# Altium schematic sheet HDMI.SchDoc
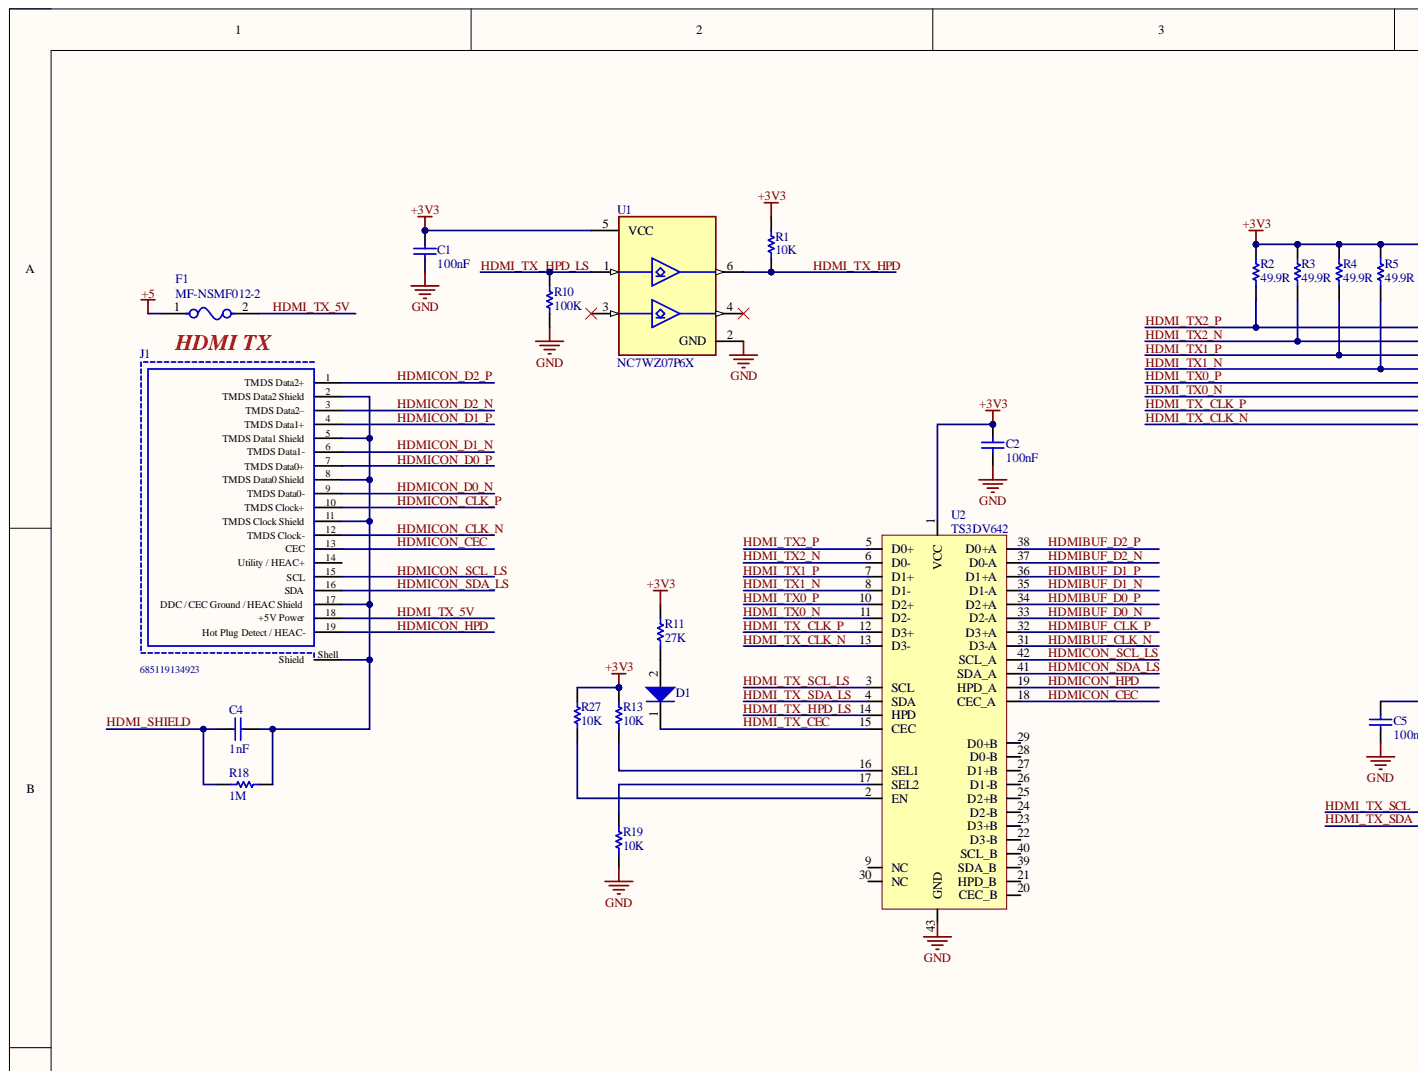
[diagram p0: HDMI.SchDoc, top left]
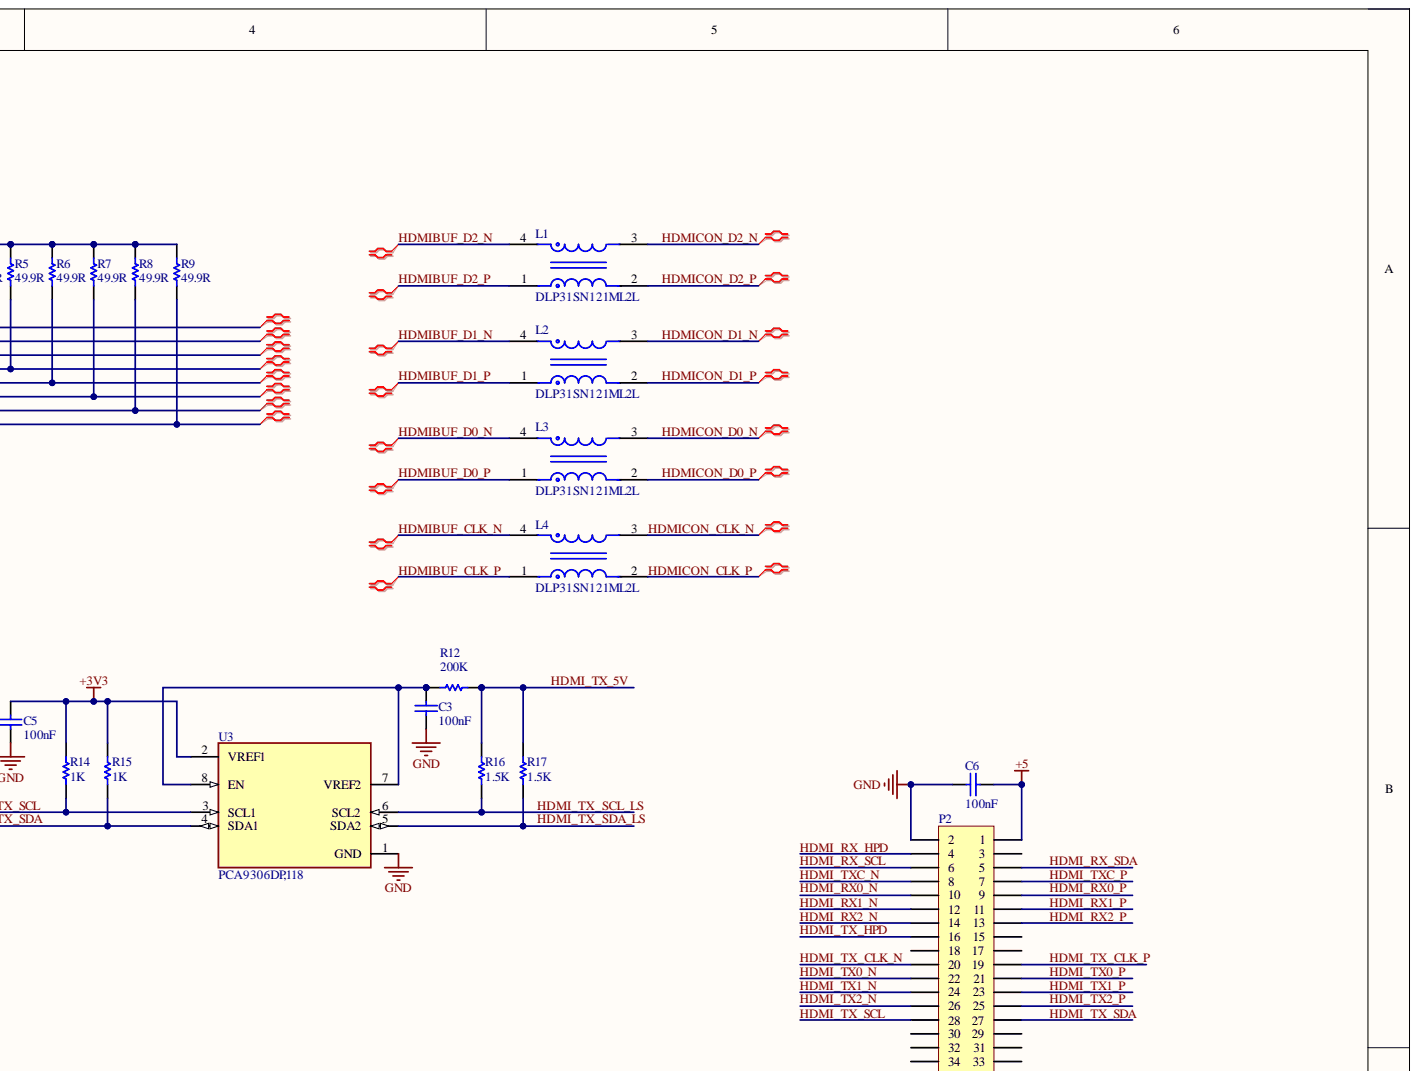
[diagram p1: HDMI.SchDoc, top right]
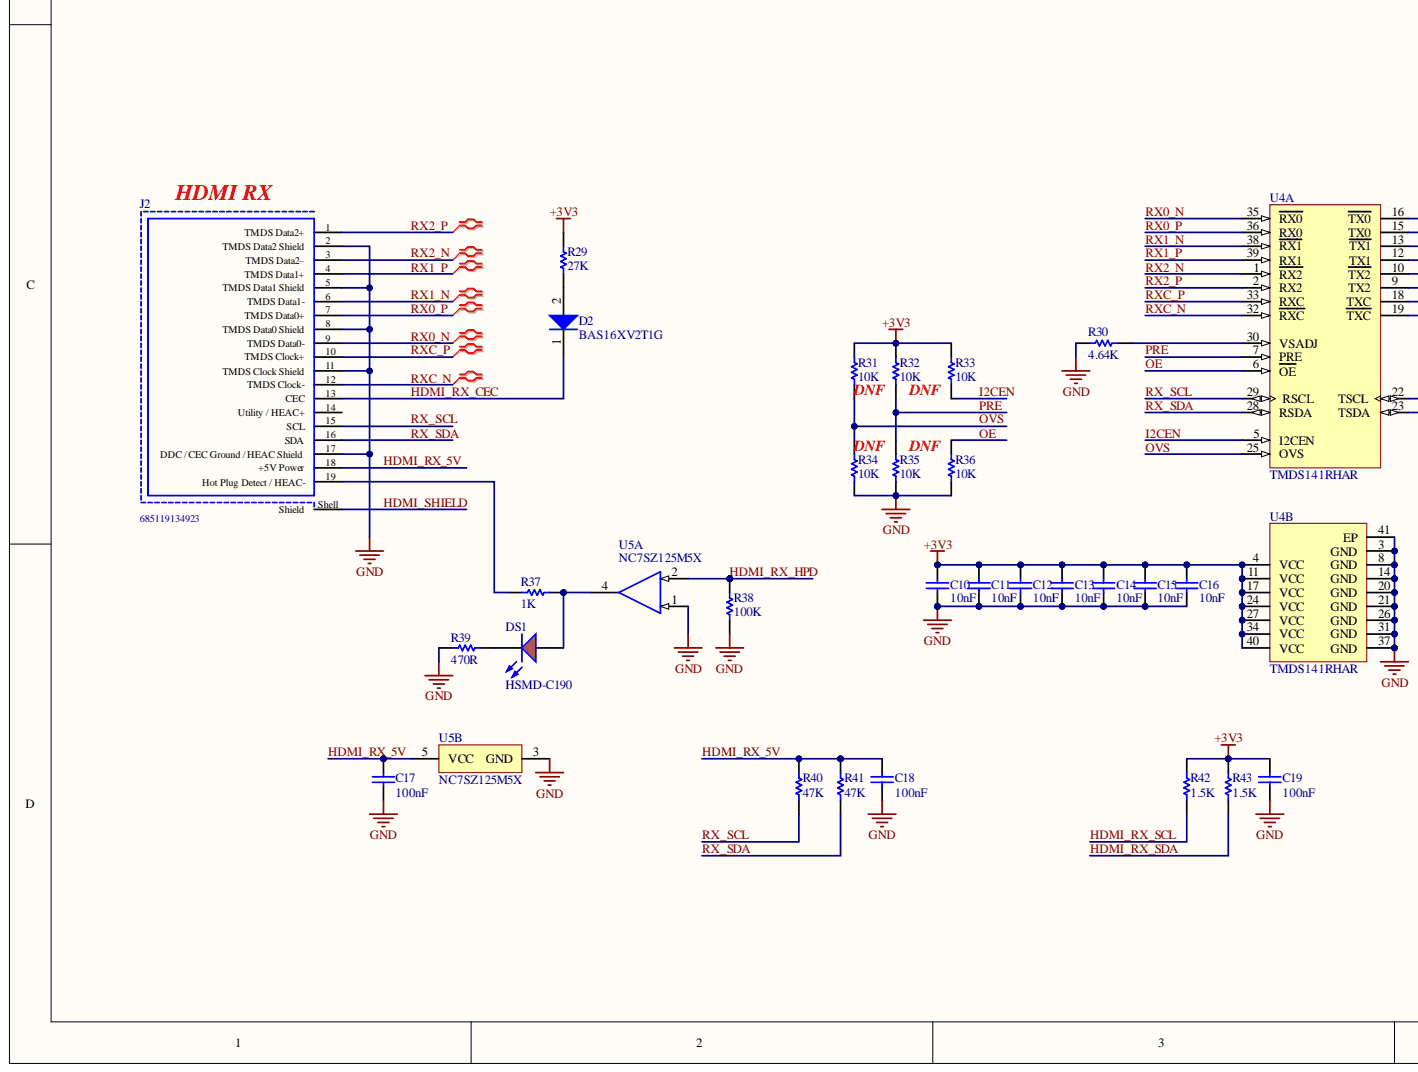
[diagram p2: HDMI.SchDoc, bottom left]
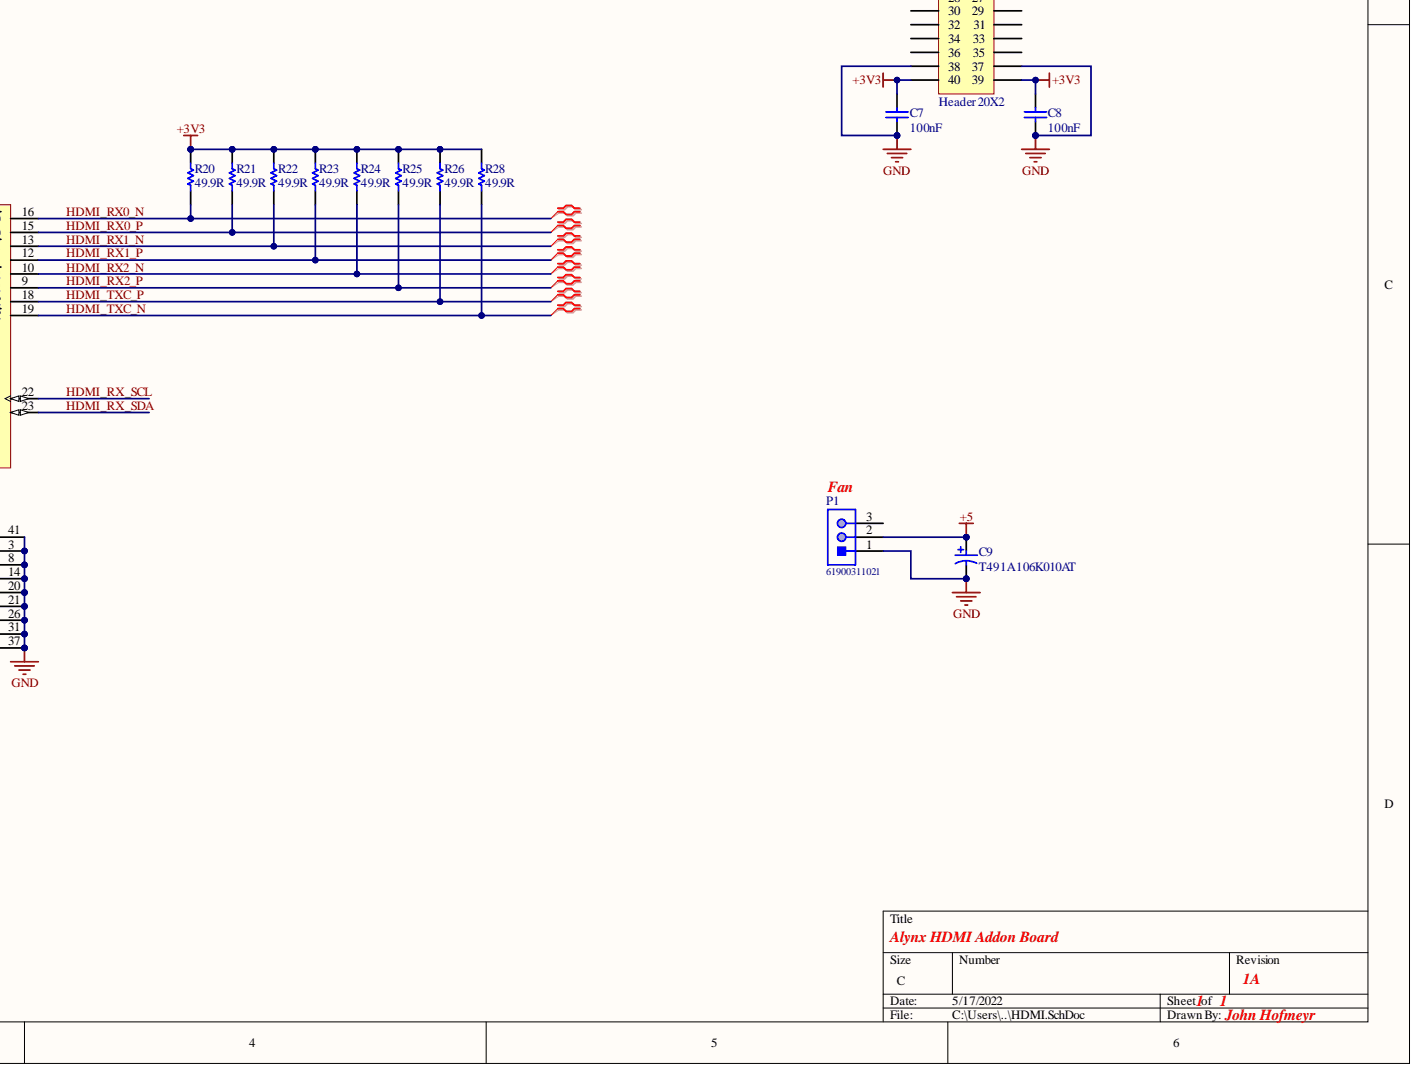
[diagram p3: HDMI.SchDoc, bottom right]

## Parts
C1  100nF  [CAPC2013X140X50NL20T25]
C2  100nF  [CAPC2013X140X50NL20T25]
C3  100nF  [CAPC2013X140X50NL20T25]
C4  1nF  [CAPC2013X100X30LL20]
C5  100nF  [CAPC2013X140X50NL20T25]
C6  100nF  [CAPC2013X140X50NL20T25]
C7  100nF  [CAPC2013X140X50NL20T25]
C8  100nF  [CAPC2013X140X50NL20T25]
C9  T491A106K010AT  [KEMT-A-3216-18-2_M]
C10  10nF  [CAPC2013X94X50ML20T25]
C11  10nF  [CAPC2013X94X50ML20T25]
C12  10nF  [CAPC2013X94X50ML20T25]
C13  10nF  [CAPC2013X94X50ML20T25]
C14  10nF  [CAPC2013X94X50ML20T25]
C15  10nF  [CAPC2013X94X50ML20T25]
C16  10nF  [CAPC2013X94X50ML20T25]
C17  100nF  [CAPC2013X140X50NL20T25]
C18  100nF  [CAPC2013X140X50NL20T25]
C19  100nF  [CAPC2013X140X50NL20T25]
D1  BAS16XV2T1G  [ONSC-SOD-523-2-502-01_V]
D2  BAS16XV2T1G  [ONSC-SOD-523-2-502-01_V]
DS1  HSMD-C190  [AVAG-HSMX-C190_V]
F1  MF-NSMF012-2  [FP-MF-NSMF012-2-MFG]
J1  685119134923  [685119134923]
J2  685119134923  [685119134923]
L1  DLP31SN121ML2L  [FP-DLP31SN-MFG]
L2  DLP31SN121ML2L  [FP-DLP31SN-MFG]
L3  DLP31SN121ML2L  [FP-DLP31SN-MFG]
L4  DLP31SN121ML2L  [FP-DLP31SN-MFG]
P1  61900311021  [61900311021]
P2  Header 20X2  [HDR2X20]
R1  10K  [RESC2013X55X40NL15T20]
R2  49.9R  [RESC2013X50X40NL20T20]
R3  49.9R  [RESC2013X50X40NL20T20]
R4  49.9R  [RESC2013X50X40NL20T20]
R5  49.9R  [RESC2013X50X40NL20T20]
R6  49.9R  [RESC2013X50X40NL20T20]
R7  49.9R  [RESC2013X50X40NL20T20]
R8  49.9R  [RESC2013X50X40NL20T20]
R9  49.9R  [RESC2013X50X40NL20T20]
R10  100K  [RESC2013X55X40LL15T20]
R11  27K  [RESC2013X50X30ML20T20]
R12  200K  [RESC2013X50X30NL20T20]
R13  10K  [RESC2013X55X40NL15T20]
R14  1K  [RESC2013X50X30NL20T20]
R15  1K  [RESC2013X50X30NL20T20]
R16  1.5K  [RESC2013X60X40LL20T25]
R17  1.5K  [RESC2013X60X40LL20T25]
R18  1M  [RESC2013X60X35LL10T20]
R19  10K  [RESC2013X55X40NL15T20]
R20  49.9R  [RESC2013X50X40NL20T20]
R21  49.9R  [RESC2013X50X40NL20T20]
R22  49.9R  [RESC2013X50X40NL20T20]
R23  49.9R  [RESC2013X50X40NL20T20]
R24  49.9R  [RESC2013X50X40NL20T20]
R25  49.9R  [RESC2013X50X40NL20T20]
R26  49.9R  [RESC2013X50X40NL20T20]
R27  10K  [RESC2013X55X40NL15T20]
R28  49.9R  [RESC2013X50X40NL20T20]
R29  27K  [RESC2013X50X30ML20T20]
R30  4.64K  [RESC2013X70X40ML20T20]
R31  10K  [RESC2013X55X40NL15T20]
R32  10K  [RESC2013X55X40NL15T20]
R33  10K  [RESC2013X55X40NL15T20]
R34  10K  [RESC2013X55X40NL15T20]
R35  10K  [RESC2013X55X40NL15T20]
R36  10K  [RESC2013X55X40NL15T20]
R37  1K  [RESC2013X50X30NL20T20]
R38  100K  [RESC2013X60X40ML20T25]
R39  470R  [RESC2013X50X30LL20T20]
R40  47K  [RESC2013X60X40LL20T25]
R41  47K  [RESC2013X60X40LL20T25]
R42  1.5K  [RESC2013X60X40LL20T25]
R43  1.5K  [RESC2013X60X40LL20T25]
U1  NC7WZ07P6X  [FP-419AD-01-MFG]
U2  TS3DV642  [QFN50P900X350X80-43N]
U3  PCA9306DP,118  [FP-SOT505-1-MFG]
U4  TMDS141RHAR  [RHA0040D_V]  (parts A,B)
U5  NC7SZ125M5X  [FAIR-SOT23-5_V]  (parts A,B)

## Nets
+3V3: C1.2 C2.2 C5.2 C7.1 C8.1 C10.2 C11.2 C12.2 C13.2 C14.2 C15.2 C16.2 C19.2 P2.39 P2.40 R1.1 R2.2 R3.2 R4.2 R5.2 R6.2 R7.2 R8.2 R9.2 R11.2 R13.2 R14.2 R15.2 R20.2 R21.2 R22.2 R23.2 R24.2 R25.2 R26.2 R27.2 R28.2 R29.2 R31.2 R32.2 R33.2 R42.2 R43.2 U1.5(VCC) U2.1(VCC) U3.2(VREF1) U4.4(VCC) U4.11(VCC) U4.17(VCC) U4.24(VCC) U4.27(VCC) U4.34(VCC) U4.40(VCC)
+5: C6.1 C9.1 F1.1 P1.2 P2.1
GND: C1.1 C2.1 C3.1 C5.1 C6.2 C7.2 C8.2 C9.2 C10.1 C11.1 C12.1 C13.1 C14.1 C15.1 C16.1 C17.1 C18.1 C19.1 J2.2(TMDS_Data2_Shield) J2.5(TMDS_Data1_Shield) J2.8(TMDS_Data0_Shield) J2.11(TMDS_Clock_Shield) J2.17(DDC_/_CEC_Ground_/_HEAC_Shield) P1.1 P2.2 P2.37 P2.38 R10.2 R19.1 R30.1 R34.1 R35.1 R36.1 R38.1 R39.1 U1.2(GND) U2.43(GND) U3.1(GND) U4.3(GND) U4.8(GND) U4.14(GND) U4.20(GND) U4.21(GND) U4.26(GND) U4.31(GND) U4.37(GND) U4.41(EP) U5.1 U5.3(GND)
HDMI_RX0_N: P2.10 R20.1 U4.16(TX0)
HDMI_RX0_P: P2.9 R21.1 U4.15(TX0)
HDMI_RX1_N: P2.12 R22.1 U4.13(TX1)
HDMI_RX1_P: P2.11 R23.1 U4.12(TX1)
HDMI_RX2_N: P2.14 R24.1 U4.10(TX2)
HDMI_RX2_P: P2.13 R25.1 U4.9(TX2)
HDMI_RX_5V: C17.2 C18.2 J2.18(+5V_Power) R40.2 R41.2 U5.5(VCC)
HDMI_RX_CEC: D2.1 J2.13(CEC)
HDMI_RX_HPD: P2.4 R38.2 U5.2
HDMI_RX_SCL: P2.6 R42.1 U4.22(TSCL)
HDMI_RX_SDA: P2.5 R43.1 U4.23(TSDA)
HDMI_SHIELD: C4.1 J2.Shell(Shield) R18.1
HDMI_TX0_N: P2.22 R7.1 U2.11(D2-)
HDMI_TX0_P: P2.21 R6.1 U2.10(D2+)
HDMI_TX1_N: P2.24 R5.1 U2.8(D1-)
HDMI_TX1_P: P2.23 R4.1 U2.7(D1+)
HDMI_TX2_N: P2.26 R3.1 U2.6(D0-)
HDMI_TX2_P: P2.25 R2.1 U2.5(D0+)
HDMI_TX_5V: F1.2 J1.18(+5V_Power) R12.1 R16.2 R17.2
HDMI_TX_CEC: D1.1 U2.15(CEC)
HDMI_TX_CLK_N: P2.20 R9.1 U2.13(D3-)
HDMI_TX_CLK_P: P2.19 R8.1 U2.12(D3+)
HDMI_TX_HPD: P2.16 R1.2 U1.6
HDMI_TX_HPD_LS: R10.1 U1.1 U2.14(HPD)
HDMI_TX_SCL: P2.28 R14.1 U3.3(SCL1)
HDMI_TX_SCL_LS: R16.1 U2.3(SCL) U3.6(SCL2)
HDMI_TX_SDA: P2.27 R15.1 U3.4(SDA1)
HDMI_TX_SDA_LS: R17.1 U2.4(SDA) U3.5(SDA2)
HDMI_TXC_N: P2.8 R28.1 U4.19(TXC)
HDMI_TXC_P: P2.7 R26.1 U4.18(TXC)
HDMIBUF_CLK_N: L4.4 U2.31(D3-A)
HDMIBUF_CLK_P: L4.1 U2.32(D3+A)
HDMIBUF_D0_N: L3.4 U2.33(D2-A)
HDMIBUF_D0_P: L3.1 U2.34(D2+A)
HDMIBUF_D1_N: L2.4 U2.35(D1-A)
HDMIBUF_D1_P: L2.1 U2.36(D1+A)
HDMIBUF_D2_N: L1.4 U2.37(D0-A)
HDMIBUF_D2_P: L1.1 U2.38(D0+A)
HDMICON_CEC: J1.13(CEC) U2.18(CEC_A)
HDMICON_CLK_N: J1.12(TMDS_Clock-) L4.3
HDMICON_CLK_P: J1.10(TMDS_Clock+) L4.2
HDMICON_D0_N: J1.9(TMDS_Data0-) L3.3
HDMICON_D0_P: J1.7(TMDS_Data0+) L3.2
HDMICON_D1_N: J1.6(TMDS_Data1-) L2.3
HDMICON_D1_P: J1.4(TMDS_Data1+) L2.2
HDMICON_D2_N: J1.3(TMDS_Data2–) L1.3
HDMICON_D2_P: J1.1(TMDS_Data2+) L1.2
HDMICON_HPD: J1.19(Hot_Plug_Detect_/_HEAC-) U2.19(HPD_A)
HDMICON_SCL_LS: J1.15(SCL) U2.42(SCL_A)
HDMICON_SDA_LS: J1.16(SDA) U2.41(SDA_A)
I2CEN: R33.1 U4.5(I2CEN)
OE: R36.2 U4.6(OE)
OVS: R31.1 R34.2 U4.25(OVS)
PRE: R32.1 R35.2 U4.7(PRE)
RX0_N: J2.9(TMDS_Data0-) U4.35(RX0)
RX0_P: J2.7(TMDS_Data0+) U4.36(RX0)
RX1_N: J2.6(TMDS_Data1-) U4.38(RX1)
RX1_P: J2.4(TMDS_Data1+) U4.39(RX1)
RX2_N: J2.3(TMDS_Data2–) U4.1(RX2)
RX2_P: J2.1(TMDS_Data2+) U4.2(RX2)
RX_SCL: J2.15(SCL) R40.1 U4.29(RSCL)
RX_SDA: J2.16(SDA) R41.1 U4.28(RSDA)
RXC_N: J2.12(TMDS_Clock-) U4.32(RXC)
RXC_P: J2.10(TMDS_Clock+) U4.33(RXC)
NetC3_2: C3.2 R12.2 U3.7(VREF2) U3.8(EN)
NetC4_2: C4.2 J1.2(TMDS_Data2_Shield) J1.5(TMDS_Data1_Shield) J1.8(TMDS_Data0_Shield) J1.11(TMDS_Clock_Shield) J1.17(DDC_/_CEC_Ground_/_HEAC_Shield) J1.Shell(Shield) R18.2
NetD1_2: D1.2 R11.1
NetD2_2: D2.2 R29.1
NetDS1_1: DS1.1 R37.1 U5.4
NetDS1_2: DS1.2 R39.2
NetJ2_19: J2.19(Hot_Plug_Detect_/_HEAC-) R37.2
NetR13_1: R13.1 U2.16(SEL1)
NetR19_2: R19.2 U2.17(SEL2)
NetR27_1: R27.1 U2.2(EN)
NetR30_2: R30.2 U4.30(VSADJ)

Not connected: J1 14(Utility_/_HEAC+); J2 14(Utility_/_HEAC+); P1 3; P2 3 15 17 18 29 30 31 32 33 34 35 36; U1 3 4; U2 9(NC) 20(CEC_B) 21(HPD_B) 22(D3-B) 23(D3+B) 24(D2-B) 25(D2+B) 26(D1-B) 27(D1+B) 28(D0-B) 29(D0+B) 30(NC) 39(SDA_B) 40(SCL_B)

## Library data of the parts
C1-C3 C5-C8 C17-C19 = CMP-2007-04629-1: Manufacturer: AVX Interconnect / Elco; Part Number: 08055C104K4Z2A; Component Kind: Standard; Library Name: Altium Content Vault
C4 = CMP-2007-03992-1: Manufacturer: Murata; Part Number: GRM21AR72E102KW01D; Component Kind: Standard; Library Name: Altium Content Vault
C9 = CMP-2000-05172-1: Capacitor, Tantalum, 10 uF, +/- 10%, 10 V, -55 to 125 degC, 2-Pin SMD (1206), RoHS, Tape and Reel; Manufacturer: Kemet; Manufacturer Size Code: A; Capacitance: 10µF; Catalog Drawings: T49x,T5xxSeries_A-side1T49x,T5xxSeries_A,B-side2T49x,T5xxSeries_A,SBottom; ESR (Equivalent Series Resistance): 3.8Ohm; Lifetime @ Temp.: 2000Hrs@85degC; Online Catalog: T491Series; Other Names: 399-3684-2; Product Training Modules: CapacitorBasics-TypicalUsesforCapacitorsDeratingGuidelinesforSurfaceMountTantalumCapacitors; Type: Molded; Voltage - Rated: 10V; Category: Capacitors; Component Kind: Standard; Datasheet URL: http://www.farnell.com/datasheets/1824869.pdf; Datasheet Version: Apr-14; Family: TantalumCapacitors; Features: GeneralPurpose; Height - Seated (Max): 0.071"(1.80mm); Lead Spacing: -; Library Name: Altium Content Vault; Manufacturer URL: http://www.kemet.com/; Mounting Type: SurfaceMount; Operating Temperature: -55degC~125degC; Package / Case: 1206(3216Metric); Package Description: 2-Pin Surface Mount Device, Body 3.2 x 1.6 mm; Package Reference: 1206; Packaging: Tape&Reel(TR); Series: T491; Size / Dimension: 0.126"Lx0.063"W(3.20mmx1.60mm); Standard Package: 2,000; Tolerance: ±10%
C10-C16 = CMP-2007-04169-1: Manufacturer: AVX Interconnect / Elco; Part Number: 08051C103KAZ2A; Component Kind: Standard; Library Name: Altium Content Vault
D1 D2 = CMP-1054-00076-1: Switching Diode, 2-Pin SOD-523, Pb-Free, Tape and Reel; Manufacturer: On Semiconductor; Configuration: Single; IF Max (A): 0.2; trr Max (ns): 6; Component Kind: Standard; ComponentLink1Description: Manufacturer URL; ComponentLink1URL: http://www.onsemi.com/; ComponentLink2Description: Datasheet; ComponentLink2URL: http://www.onsemi.com/pub_link/Collateral/BAS16XV2T1-D.PDF; ComponentLink3Description: Package Specification; ComponentLink3URL: http://www.onsemi.com/pub_link/Collateral/502-01.PDF; DatasheetVersion: Rev. 7; Library Name: Altium Content Vault; Mounting Technology: Surface Mount; PackageDescription: 2-Pin SOD, Body 1.2 x 0.8 mm; PackageReference: SOD-523-2-502-01; PackageVersion: Rev. E, 09/2010; Packing: Tape and Reel; PartNumber: BAS16XV2T1G; RoHS: TRUE
DS1 = CMP-1744-00006-1: LED Uni-Color Orange, 52 mW, 20 mA, -40 to 85 degC, 2-Pin SMD, RoHS, Tape and Reel; Component Kind: Standard; ComponentLink1Description: Manufacturer URL; ComponentLink1URL: http://www.avagotech.com/; ComponentLink2Description: Datasheet; ComponentLink2URL: http://docs.avagotech.com/docs/AV02-0551EN; ComponentLink3Description: Package Specification; ComponentLink3URL: http://www.fairchildsemi.com/dwg/MA/MA03D.pdf; DatasheetVersion: Mar-12; Library Name: Altium Content Vault; Max Operating Temperature: 225degC; Min Operating Temperature: -40degC; Package Quantity: 100; PackageDescription: 2-Pin Surface Mount Device, Body 1.6 x 0.8 mm, Height 0.9 mm; PackageReference: HSMX-C190; RoHSCompliant: True
F1 = CMP-07400-000025-1: PTC RESET FUSE 30V 120MA 1206; Manufacturer: Bourns Inc.; Automotive Grade: Grade 3; Current Rating: 10A; Hold Current: 0.12A; Resistance: 1.35-8.5Ω; Trip Current: 0.29A; Trip Time: 0.2s; Value: 1.35-8.5Ω; Ambient Temperature Range High: +85°C; Ambient Temperature Range Low: -40°C; Automotive: Yes; Category: Fuse; Component Kind: Standard; ComponentLink2Description: Datasheet; ComponentLink2URL: https://www.bourns.com/docs/Product-Datasheets/mfnsmf.pdf; Device Class L1: Circuit Protection; Device Class L2: PTC Resettable Fuses; Device Class L3: unset; Digikey Description: PTC RESET FUSE 30V 120MA 1206; Height: 1.1mm; Lead Free: Yes; Library Name: Altium Content Vault; Manufacturer Part Number: MF-NSMF012-2; Mouser Description: Resettable Fuses - PPTC 0.12A 30V 1.35ohm; Octopart: https://octopart.com/mf-nsmf012-2-bourns-24569; Package: MF-NSMF012-2; RoHS: TRUE; Temperature: -40°C to +85°C; Temperature Range High: +85°C; Temperature Range Low: -40°C; Voltage Rating: 30V
J1 J2 = CMP-1502-00169-1: HDMI Type A Receptacle WR-HDMI, Horizontal, SMT; Manufacturer: Wurth Elektronik; Contact Resistance: 30mOhm; Rated Current: 0.5A; Working Voltage: 40VDC; Component Kind: Standard; Connector Type: HDMITypeA; Datasheet URL: https://katalog.we-online.de/em/datasheet/685119134923.pdf; Gender: Receptacle; Library Name: Altium Content Vault; Manufacturer URL: http://www.we-online.de; Mounting Technology: SMT; Operating Temperature: -20to85degC; Orientation: Horizontal; Package Reference: 685119134923; Packaging: TapeandReel; Part Number: 685119134923; Publisher: WurthElektronik
L1-L4 = CMP-06045-000038-1: CMC 100MA 2LN 120 OHM SMD; DC Resistance (DCR): 2R; Impedance: 120R; Number of Lines: 2; Shielding: Unshielded; Test Frequency: 100MHz; Tolerance: 20%; Voltage Rating: 16V; Case Code (Imperial): 1206; Case Code (Metric): 3216; Case/Package: 1206; Component Kind: Standard; Current: 100mA; Current Rating: 100mA; DC Current: 100mA; Depth: 1.75mm; Height: 1.15mm; Lead Free: Lead Free; Length: 3.2mm; Library Name: Altium Content Vault; Max DC Current: 100mA; Max Operating Temperature: 85°C; Military Standard: Not; Min Operating Temperature: -40°C; Mount: Surface Mount; Package Quantity: 1; Packaging: Tape and Reel; Radiation Hardening: No; Termination: SMD/SMT; Width: 0.063inch
  L1: Supplier 1: Digi-Key
P1 = CMP-1502-00593-1: Male Bottom Locking Header WR-WTB, THT, Right Angled, pitch 2.54 mm, 1 x 3 position; Manufacturer: Wurth Elektronik; Contact Resistance: 20mOhm; Pitch: 2.54mm; Position: 1x3; Working Voltage: 250VAC; Component Kind: Standard; Datasheet URL: https://katalog.we-online.de/em/datasheet/6190xx11021.pdf; Gender: Male; Library Name: Altium Content Vault; Manufacturer URL: http://www.we-online.de; Mounting Technology: THT; Operating Temperature: -40to105degC; Orientation: RightAngled; Package Reference: 61900311021; Packaging: Bag; Part Number: 61900311021; Publisher: WurthElektronik
P2 = Header 20X2: Header, 20-Pin, Dual row; Component Kind: Standard; LatestRevisionDate: 17-Jul-2002; LatestRevisionNote: Re-released for DXP Platform.; Library Name: Miscellaneous Connectors.IntLib; Signal Integrity: Connector; Publisher: Altium Limited
R1 R13 R19 R27 R31-R36 = CMP-2001-04726-1: Manufacturer: Vishay Dale; Part Number: TNPW080510K0BETA; Component Kind: Standard; Library Name: Altium Content Vault
R2-R9 R20-R26 R28 = CMP-2001-03560-1: Manufacturer: Susumu; Part Number: RR1220Q-49R9-D-M; Component Kind: Standard; Library Name: Altium Content Vault
R10 = CMP-2001-04720-1: Manufacturer: Vishay Dale; Part Number: TNPW0805100KBEEA; Component Kind: Standard; Library Name: Altium Content Vault
R11 R29 = CMP-2001-03741-1: Manufacturer: Vishay Dale; Part Number: CRCW080527K0FKEA; Component Kind: Standard; Library Name: Altium Content Vault
R12 = CMP-2001-03660-1: Manufacturer: Vishay Dale; Part Number: CRCW0805200KFKEA; Component Kind: Standard; Library Name: Altium Content Vault
R14 R15 R37 = CMP-1013-00510-2: Manufacturer: Vishay Dale; Part Number: CRCW08051K00FKEA; Component Kind: Standard; Library Name: Altium Content Vault
R16 R17 R42 R43 = CMP-2001-00597-1: Manufacturer: Panasonic; Part Number: ERA6AEB152V; Component Kind: Standard; Library Name: Altium Content Vault
R18 = CMP-2001-01721-1: Manufacturer: Yageo; Part Number: RT0805BRD071ML; Component Kind: Standard; Library Name: Altium Content Vault
R30 = CMP-1013-00585-2: Manufacturer: Panasonic; Part Number: ERJ-6ENF4641V; Component Kind: Standard; Library Name: Altium Content Vault
R38 = CMP-2001-00583-1: Manufacturer: Panasonic; Part Number: ERA6AEB104V; Component Kind: Standard; Library Name: Altium Content Vault
R39 = CMP-2001-03932-1: Manufacturer: Vishay Dale; Part Number: CRCW0805470RFKEA; Component Kind: Standard; Library Name: Altium Content Vault
R40 R41 = CMP-2001-00645-1: Manufacturer: Panasonic; Part Number: ERA6AEB473V; Component Kind: Standard; Library Name: Altium Content Vault
U1 = CMP-2000-06822-2: IC BUF NON-INVERT 5.5V SC70-6; High Level Output Current: 32mA; Logic Function: Buffer; Max Power Dissipation: 180mW; Max Supply Current: 24mA; Min Supply Voltage: 1.65V; Number of Bits: 2; Number of Bits per Element: 1; Polarity: Non-Inverting; Propagation Delay: 12.6ns; Quiescent Current: 1uA; Turn-On Delay Time: 11.5ns; Case/Package: SC; Component Kind: Standard; Height: 1mm; Lead Free: Lead Free; Length: 2mm; Library Name: Altium Content Vault; Low Level Output Current: 32mA; Max Operating Temperature: 85°C; Max Supply Voltage: 5.5V; Min Operating Temperature: -40°C; Mount: Surface Mount; Number of Channels: 2; Number of Circuits: 2; Number of Elements: 2; Number of Input Lines: 2; Number of Inputs: 2; Number of Output Lines: 2; Number of Outputs: 2; Number of Pins: 6; Package Quantity: 1; Packaging: Tape and Reel; Radiation Hardening: No; REACH SVHC: No SVHC; Termination: SMD/SMT; Weight: 0.028g; Width: 1.25mm
U3 = CMP-10125-000003-1: IC TRNSLTR BIDIRECTIONAL 8TSSOP; Manufacturer: NXP; Logic Function Desc: Non-Inverting; Nominal Supply Current: 128mA; Number Of Circuits: 1; Standoff Height: 0.05mm; V A Max: 3.6V; V A Min: 1V; V B Max: 5.5V; V B Min: 1.8V; Ambient Temperature Range High: +85°C; Ambient Temperature Range Low: -40°C; Automotive: No; Category: IC; Component Kind: Standard; ComponentLink2Description: Datasheet; ComponentLink2URL: https://www.nxp.com/docs/en/data-sheet/PCA9306.pdf; Device Class L1: Integrated Circuits (ICs); Device Class L2: Logic ICs; Device Class L3: Voltage Level Shifters; Digikey Description: IC TRNSLTR BIDIRECTIONAL 8TSSOP; Footprint Url: https://www.nxp.com/docs/en/package-information/SOT505-1.pdf; Height: 1.1mm; Lead Free: Yes; Library Name: Altium Content Vault; Manufacturer Part Number: PCA9306DP,118; Mouser Description: Translation - Voltage Levels DUAL I2C/SMBUS VOLT TRANSL; Number Of Inputs: 1; Number Of Outputs: 1; Octopart: https://octopart.com/pca9306dp%2C118-nxp+semiconductors-11541843; Package: SOT505-1; RoHS: TRUE; Temperature: -40°C to +85°C; Temperature Range High: +85°C; Temperature Range Low: -40°C
U4 = CMP-0325-00044-3: HDMI Hider, 130 mA, 6 kV, 0 to +70 degC, 40-pin QFN (RHA), Green (RoHS & no Sb/Br); Manufacturer: Texas Instruments; Clock P-P Jitter(Max)(ps): 30; Data P-P Jitter(Max)(ps): 90; ESD HBM(kV): 6; ICC(Max)(mA): 130; Inter-Pair Skew(Max)(ps): 80; Intra-Pair Skew(Max)(ps): 60; No. of TMDS Inputs: 1; SubFamily: DVI/HDMI Repeater/Switch; TMDS Input Equalization: TRUE; Component Kind: Standard; ComponentLink1Description: Manufacturer URL; ComponentLink1URL: http://www.ti.com/; ComponentLink2Description: Datasheet; ComponentLink2URL: http://www.ti.com/general/docs/lit/getliterature.tsp?genericPartNumber=TMDS141&fileType=pdf; ComponentLink3Description: URL Link1; ComponentLink3URL: SamplesURL:http://www.ti.com/product/tmds141#samples; DatasheetVersion: SLLS737D; Eco-Plan: Green (RoHS & no Sb/Br); Library Name: Altium Content Vault; Mounting Technology: Surface Mount; No. of TMDS Outputs: 1; PackageDescription: 40-Pin Plastic Quad Flatpack No-Lead, 6 x 6 mm Body, 0.5 mm Pitch; PackageReference: RHA0040D; PackageVersion: revL, May-2012; PartNumber: TMDS141RHAR; TMDS Output De-emphasis: TRUE
U5 = CMP-2000-06816-1: TinyLogic UHS Buffer with Three-State Output, 1.65 to 5.5 V, -40 to 85 degC, 5-Pin SOT23, RoHS, Tape and Reel; Manufacturer: Fairchild Semiconductor; Bus Hold: No; Fabrication Technology: CMOS; Input Signal Type: Single-Ended; Lead Finish: MatteTin; Logic Function: Buffer/LineDriver; Max Processing Temp: 260; Maximum High Level Output Current: -32mA; Maximum Low Level Output Current: 32mA; Maximum Operating Supply Voltage: 5.5V; Maximum Propagation Delay Time @ Maximum CL: 5.7@3.3V; Maximum Quiescent Current: 2uA; Minimum Operating Supply Voltage: 1.65V; Number of Channels per Chip: 1; Number of Output Enables per Chip: 1Low; Output Type: 3-State; Package Version: 08/2015; Polarity: Non-Inverting; Propagation Delay Test Condition: 50pF; Tolerant I/Os: 5V; Typical Operating Supply Voltage: 1.8; Component Kind: Standard; Datasheet URL: https://www.fairchildsemi.com/datasheets/NC/NC7SZ125.pdf; Datasheet Version: Rev. 1.0.5, 01/2014; Library Name: Altium Content Vault; Manufacturer URL: https://www.fairchildsemi.com/; Mounting: SurfaceMount; Mounting Technology: SurfaceMount; Number of Elements per Chip: 1; Number of Inputs per Chip: 1; Number of Outputs per Chip: 1; Operating Temperature: -40to85C; Package Description: 5-Pin Small Outline Transistor, Body 2.9 x 1.6 mm, Pitch 0.95 mm; Package Reference: SOT23-5; Package URL: https://www.fairchildsemi.com/package-drawings/MA/MA05B.pdf
U2 = TS3DV642: Component Kind: Standard; Library Name: Schlib1.SchLib; Supplier 1: Digi-Key; Supplier Part Number 1: 296-39510-1-ND
